# Altium schematic sheet Teensy Connections for SSS2 Rev 5.SchDoc("Teensy Connections for SSS2 Rev 5")
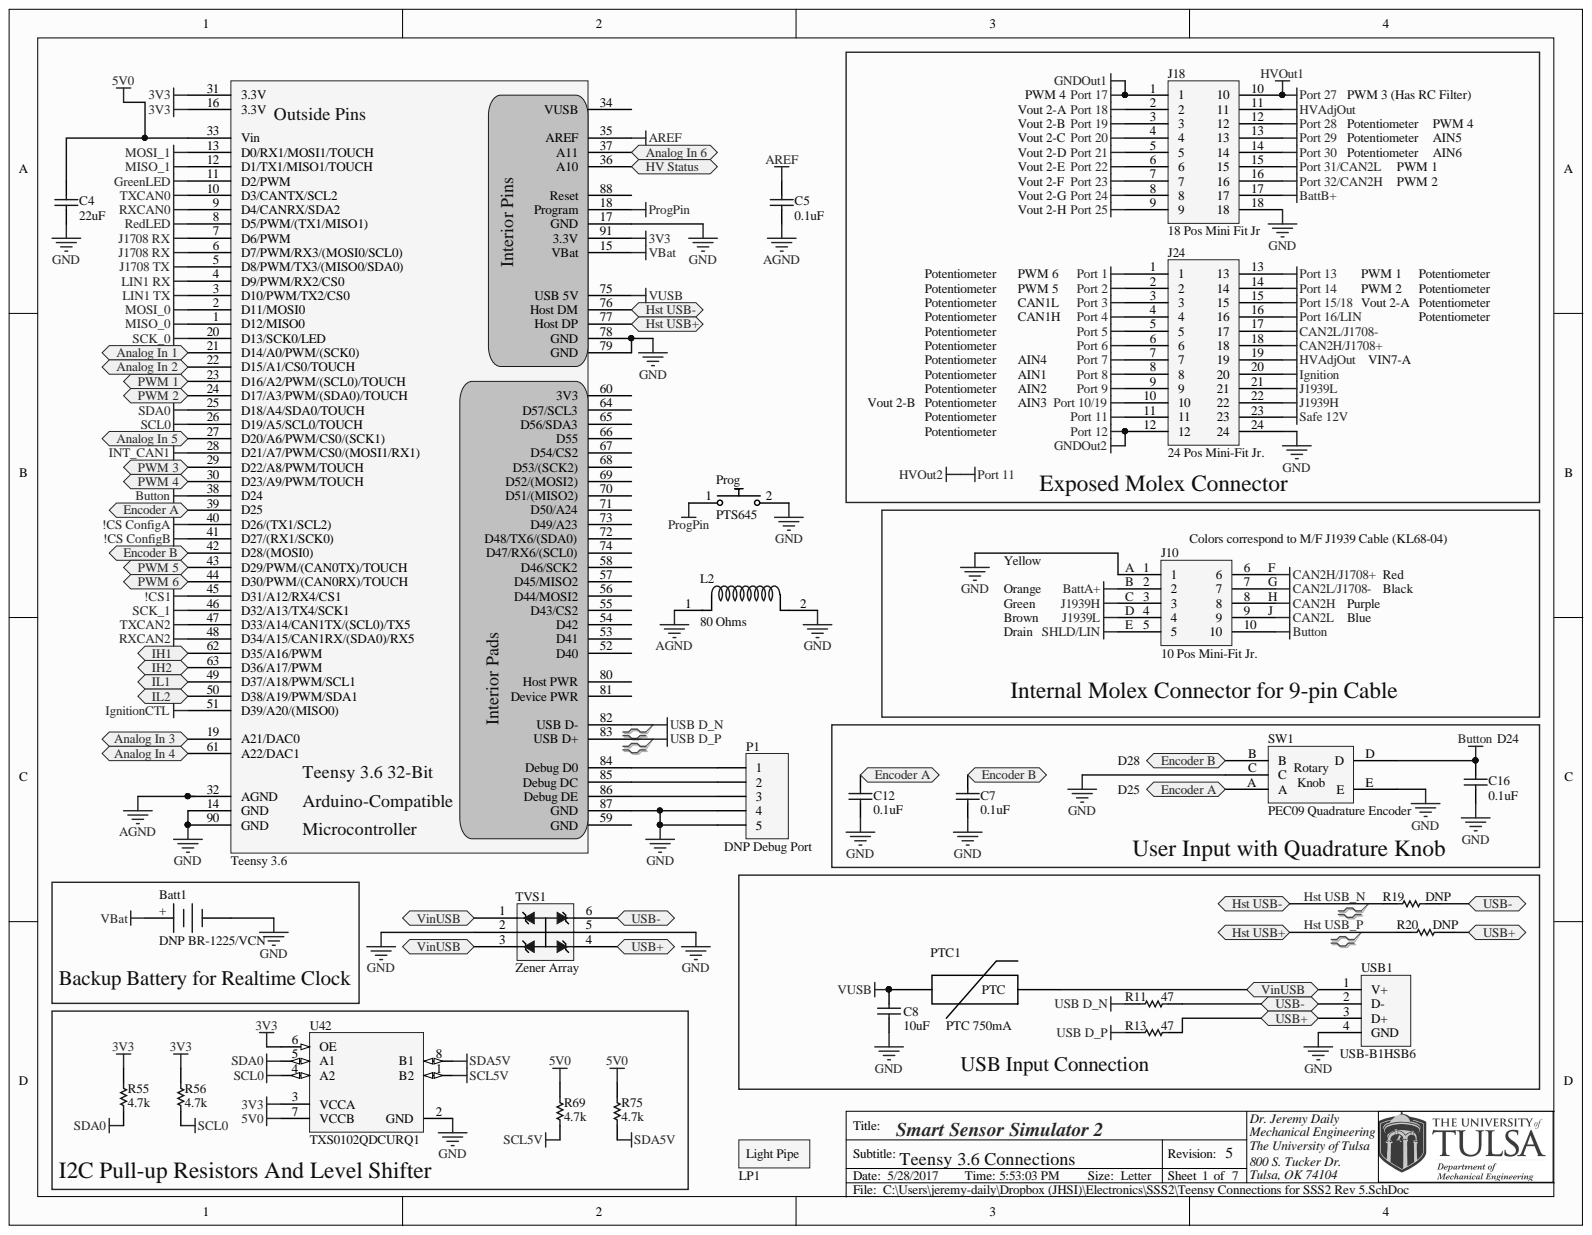
[diagram p0: Teensy Connections for SSS2 Rev 5.SchDoc]

## Parts
Batt1  DNP BR-1225/VCN  PartNumber: BR-1225/VCN  [Coin Cell BR-1225/VCN]
C4  22uF  [1206]
C5  0.1uF  [0603]
C7  0.1uF  [0603]
C8  10uF  [0603]
C12  0.1uF  [0603]
C16  0.1uF  [0603]
J10  10 Pos Mini-Fit Jr.  [10 pos Mini-Fit Jr. R/A Header]
J18  18 Pos Mini Fit Jr  [18 pos Mini-Fit Jr. R/A Header]
J24  24 Pos Mini-Fit Jr.  [24 pos Mini-Fit Jr. R/A Header]
L2  80 Ohms  [0805]
LP1  DNP Light Pipe  [Lightpipe - Dual]  (value not drawn)
P1  DNP Debug Port  [HDR1X5]
Prog  PTS645  [Vertical Tactile Switch]
PTC1  PTC 750mA  [1206]
R11  47  [0603]
R13  47  [0603]
R19  DNP  [0603]
R20  DNP  [0603]
R55  4.7k  [0603]
R56  4.7k  [0603]
R69  4.7k  [0603]
R75  4.7k  [0603]
SW1  PEC09 Quadrature Encoder  [PEC09 Series Encoder]
Teensy_3.6  Teensy 3.6  [Teensy 3.6 Through Hole - No Labels]
TVS1  Zener Array  [SOT23-6]
U42  TXS0102QDCURQ1  [DCU0008A_L]
USB1  USB-B1HSB6  [USB B Connector]

## Ports
ANALOG IN 1, ANALOG IN 2, ANALOG IN 3, ANALOG IN 4, ANALOG IN 5, ANALOG IN 6, ENCODER A, ENCODER B, HST USB+, HST USB-, HV STATUS, IH1, IH2, IL1, IL2, PWM 1, PWM 2, PWM 3, PWM 4, PWM 5, PWM 6, USB+, USB-, VINUSB

## Nets
3V3: R55.2 R56.2 Teensy_3.6.16(3.3V) Teensy_3.6.31(3.3V) Teensy_3.6.91(3.3V) U42.3(VCCA) U42.6(OE)
5V0: C4.2 R69.2 R75.2 Teensy_3.6.33(Vin) U42.7(VCCB)
!CS1: Teensy_3.6.45(D31/A12/RX4/CS1)
!CS CONFIGA: Teensy_3.6.40(D26/(TX1/SCL2))
!CS CONFIGB: Teensy_3.6.41(D27/(RX1/SCK0))
AGND: C5.1 L2.1 Teensy_3.6.32(AGND)
AREF: C5.2 Teensy_3.6.35(AREF)
BATTA+: J10.2
BATTB+: J18.17
BUTTON: C16.2 J10.10 SW1.D Teensy_3.6.38(D24)
CAN2H: J10.8
CAN2H/J1708+: J10.6 J24.18
CAN2L: J10.9
CAN2L/J1708-: J10.7 J24.17
GND: Batt1.2 C4.1 C7.1 C8.2 C12.1 C16.1 J10.1 J18.18 J24.24 L2.2 P1.4 P1.5 Prog.2 SW1.C SW1.E Teensy_3.6.14(GND) Teensy_3.6.17(GND) Teensy_3.6.78(GND) Teensy_3.6.79(GND) Teensy_3.6.87(GND) Teensy_3.6.90(GND) TVS1.2 TVS1.5 U42.2(GND) USB1.4(GND)
GNDOUT1: J18.1
GNDOUT2: J24.12
GREENLED: Teensy_3.6.11(D2/PWM)
HVADJOUT: J18.11 J24.19
HVOUT1: J18.10
HVOUT2: J24.11
IGNITION: J24.20
IGNITIONCTL: Teensy_3.6.51(D39/A20/(MISO0))
INT_CAN1: Teensy_3.6.28(D21/A7/PWM/CS0/(MOSI1/RX1))
J1708 RX: Teensy_3.6.6(D7/PWM/RX3/(MOSI0/SCL0)) Teensy_3.6.7(D6/PWM)
J1708 TX: Teensy_3.6.5(D8/PWM/TX3/(MISO0/SDA0))
J1939H: J10.3 J24.22
J1939L: J10.4 J24.21
LIN1 RX: Teensy_3.6.4(D9/PWM/RX2/CS0)
LIN1 TX: Teensy_3.6.3(D10/PWM/TX2/CS0)
MISO_0: Teensy_3.6.1(D12/MISO0)
MISO_1: Teensy_3.6.12(D1/TX1/MISO1/TOUCH)
MOSI_0: Teensy_3.6.2(D11/MOSI0)
MOSI_1: Teensy_3.6.13(D0/RX1/MOSI1/TOUCH)
PORT 1: J24.1
PORT 2: J24.2
PORT 3: J24.3
PORT 4: J24.4
PORT 5: J24.5
PORT 6: J24.6
PORT 7: J24.7
PORT 8: J24.8
PORT 9: J24.9
PORT 10/19: J24.10
PORT 13: J24.13
PORT 14: J24.14
PORT 15/18: J24.15
PORT 16/LIN: J24.16
PORT 18: J18.2
PORT 19: J18.3
PORT 20: J18.4
PORT 21: J18.5
PORT 22: J18.6
PORT 23: J18.7
PORT 24: J18.8
PORT 25: J18.9
PORT 28: J18.12
PORT 29: J18.13
PORT 30: J18.14
PORT 31/CAN2L: J18.15
PORT 32/CAN2H: J18.16
PROGPIN: Prog.1 Teensy_3.6.18(Program)
REDLED: Teensy_3.6.8(D5/PWM/(TX1/MISO1))
RXCAN0: Teensy_3.6.9(D4/CANRX/SDA2)
RXCAN2: Teensy_3.6.48(D34/A15/CAN1RX/(SDA0)/RX5)
SAFE 12V: J24.23
SCK_0: Teensy_3.6.20(D13/SCK0/LED)
SCK_1: Teensy_3.6.46(D32/A13/TX4/SCK1)
SCL0: R56.1 Teensy_3.6.26(D19/A5/SCL0/TOUCH) U42.4(A2)
SCL5V: R69.1 U42.1(B2)
SDA0: R55.1 Teensy_3.6.25(D18/A4/SDA0/TOUCH) U42.5(A1)
SDA5V: R75.1 U42.8(B1)
SHLD/LIN: J10.5
TXCAN0: Teensy_3.6.10(D3/CANTX/SCL2)
TXCAN2: Teensy_3.6.47(D33/A14/CAN1TX/(SCL0)/TX5)
USB D_N: R11.1 Teensy_3.6.82(USB_D-)
USB D_P: R13.1 Teensy_3.6.83(USB_D+)
VBAT: Batt1.1 Teensy_3.6.15(VBat)
VUSB: C8.1 PTC1.1 Teensy_3.6.75(USB_5V)
HST USB_N: R19.1 Teensy_3.6.76(Host_DM)
HST USB_P: R20.1 Teensy_3.6.77(Host_DP)
IH1: Teensy_3.6.62(D35/A16/PWM) ; port IH1
IH2: Teensy_3.6.63(D36/A17/PWM) ; port IH2
IL1: Teensy_3.6.49(D37/A18/PWM/SCL1) ; port IL1
IL2: Teensy_3.6.50(D38/A19/PWM/SDA1) ; port IL2
PWM 1: Teensy_3.6.23(D16/A2/PWM/(SCL0)/TOUCH) ; port PWM 1
PWM 2: Teensy_3.6.24(D17/A3/PWM/(SDA0)/TOUCH) ; port PWM 2
PWM 3: Teensy_3.6.29(D22/A8/PWM/TOUCH) ; port PWM 3
PWM 4: Teensy_3.6.30(D23/A9/PWM/TOUCH) ; port PWM 4
PWM 5: Teensy_3.6.43(D29/PWM/(CAN0TX)/TOUCH) ; port PWM 5
PWM 6: Teensy_3.6.44(D30/PWM/(CAN0RX)/TOUCH) ; port PWM 6
NetC7_2: C7.2 SW1.B Teensy_3.6.42(D28/(MOSI0)) ; port ENCODER B
NetC12_2: C12.2 SW1.A Teensy_3.6.39(D25) ; port ENCODER A
NetP1_1: P1.1 Teensy_3.6.84(Debug_D0)
NetP1_2: P1.2 Teensy_3.6.85(Debug_DC)
NetP1_3: P1.3 Teensy_3.6.86(Debug_DE)
NetPTC1_2: PTC1.2 TVS1.1 TVS1.3 USB1.1(V+) ; port VINUSB
NetR11_2: R11.2 R19.2 TVS1.6 USB1.2(D-)
NetR13_2: R13.2 R20.2 TVS1.4 USB1.3(D+)
NetTeensy 3.6_19: Teensy_3.6.19(A21/DAC0) ; port ANALOG IN 3
NetTeensy 3.6_21: Teensy_3.6.21(D14/A0/PWM/(SCK0)) ; port ANALOG IN 1
NetTeensy 3.6_22: Teensy_3.6.22(D15/A1/CS0/TOUCH) ; port ANALOG IN 2
NetTeensy 3.6_27: Teensy_3.6.27(D20/A6/PWM/CS0/(SCK1)) ; port ANALOG IN 5
NetTeensy 3.6_36: Teensy_3.6.36(A10) ; port HV STATUS
NetTeensy 3.6_37: Teensy_3.6.37(A11) ; port ANALOG IN 6
NetTeensy 3.6_61: Teensy_3.6.61(A22/DAC1) ; port ANALOG IN 4

Not connected: Teensy_3.6 34(VUSB) 52(D40) 53(D41) 54(D42) 55(D43/CS2) 56(D44/MOSI2) 57(D45/MISO2) 58(D46/SCK2) 59(GND) 60(3V3) 64(D57/SCL3) 65(D56/SDA3) 66(D55) 67(D54/CS2) 68(D53/(SCK2)) 69(D52/(MOSI2)) 70(D51/(MISO2)) 71(D50/A24) 72(D48/TX6/(SDA0)) 73(D49/A23) 74(D47/RX6/(SCL0)) 80(Host_PWR) 81(Device_PWR) 88(Reset)

## Library data of the parts
Batt1 = CR2032 Battery Holder: Lithium Battery Non-Rechargeable (Primary) 3V Coin, 12.5mm; Manufacturer: Panasonic; Component Kind: Standard; Library Name: SSS Integrated Library.IntLib; Supplier 1: Digi-Key; Supplier Part Number 1: P192-ND
C4 = Cap: 22µF ±20% 25V Ceramic Capacitor X5R 1206 (3216 Metric); Manufacturer: Murata Electronics North America; PartNumber: GRT31CR61E226ME01L; Component Kind: Standard; Library Name: SSS Integrated Library.IntLib; Signal Integrity: Cap; Simulation: CAP; Supplier 1: Digi-Key; Supplier Part Number 1: 490-12451-1-ND
C5 C7 C12 C16 = Cap: CAP CER 0.1UF 50V 10% X7R 0603; Manufacturer: Murata Electronics North America; PartNumber: GRM188R71H104KA93D; Component Kind: Standard; Library Name: SSS Schematic Library.SchLib; Signal Integrity: Cap; Simulation: CAP; Supplier 1: Digi-Key; Supplier Part Number 1: 490-1519-1-ND
C8 = Cap: 10µF ±20% 25V Ceramic Capacitor X5R 0603 (1608 Metric); Manufacturer: Murata Electronics North America; PartNumber: GRT188R61E106ME13D; Component Kind: Standard; Library Name: SSS Integrated Library.IntLib; Signal Integrity: Cap; Simulation: CAP; Supplier 1: Digi-Key; Supplier Part Number 1: 490-12323-1-ND
J10 = 10 Pos Header: 10 Positions Header - Shrouded Connector 0.165in (4.20mm) Through Hole - Right Angle Gold; Manufacturer: Molex; Component Kind: Standard; Library Name: Molex Mini-Fit Jr.IntLib; PartNumber: 39-30-1102; Supplier 1: Digi-Key; Supplier Part Number 1: WM21367-ND
J18 = 18 Pos Header: 18 Positions Header - Shrouded Connector 0.165in (4.20mm) Through Hole - Right Angle Gold; Manufacturer: Molex; Component Kind: Standard; Library Name: Molex Mini-Fit Jr.IntLib; PartNumber: 39-30-1182; Supplier 1: Digi-Key; Supplier Part Number 1: WM21371-ND
J24 = 24 Pos Header: 24 Positions Header  Shrouded Connector 0.165in (4.20mm) Through Hole  Right Angle Gold; Manufacturer: Molex; Component Kind: Standard; Library Name: Molex Mini-Fit Jr.IntLib; PartNumber: 39-30-1242; Supplier 1: Digi-Key; Supplier Part Number 1: WM21374-ND
L2 = Ferrite Bead: Ferrite Bead 80ohms 100MHz 5A Monolithic 0805 SMD; Manufacturer: Laird Technologies; PartNumber: HI0805R800R-10; Component Kind: Standard; Library Name: SSS Integrated Library.IntLib; Supplier 1: Mouser; Supplier Part Number 1: 875-HI0805R800R-10
LP1 = Light Pipe: Light Pipe 2 High Clear Rigid Round with Domed Top, 3mm Board Mount, Press Fit, Right Angle; Manufacturer: Bivar Inc.; PartNumber: LP-230; Component Kind: Standard; Library Name: SSS Schematic Library.SchLib; Supplier 1: Digi-Key; Supplier Part Number 1: 492-1859-ND
P1 = Header 5: Header, 5-Pin; Component Kind: Standard; Library Name: Miscellaneous Connectors.IntLib; Signal Integrity: Connector
Prog = Switch - Tactile: PTS645 Series  6 mm Tact Switches; Manufacturer: C & K Components; PartNumber: PTS645VL39-2 LFS; Component Kind: Standard; Library Name: TU Custom Parts Schematics.SchLib; Supplier 1: Digi-Key; Supplier Part Number 1: CKN9103-ND
PTC1 = PTC: PTC RESTTBLE 0.75A 16V CHIP 1206; Manufacturer: Bel Fuse Inc; PartNumber: 0ZCJ0075AF2E; Component Kind: Standard; Library Name: SSS Schematic Library.SchLib; Supplier 1: Digi-Key; Supplier Part Number 1: 507-1805-1-ND
R11 R13 R19 R20 = Chip Resistor: RES SMD 47 OHM 1% 1/10W 0603; Manufacturer: Panasonic Electronic Components; PartNumber: ERJ-3EKF47R0V; Component Kind: Standard; Library Name: SSS Integrated Library.IntLib; Supplier 1: Digi-Key; Supplier Part Number 1: P47.0HCT-ND
R55 R56 R69 R75 = Chip Resistor: RES SMD 4.7K OHM 1% 1/10W 0603; Manufacturer: Panasonic Electronic Components; PartNumber: ERJ-3EKF4701V; Component Kind: Standard; Library Name: SSS Integrated Library.IntLib; Supplier 1: Digi-Key; Supplier Part Number 1: P4.70KHCT-ND
SW1 = Quadrature PEC09: ENCODER INCREMENTAL W/SWITCH 9MM; Manufacturer: Bourns Inc.; PartNumber: PEC09-2220F-S0012; Component Kind: Standard; Library Name: SSS Integrated Library.IntLib; Supplier 1: Digi-Key; Supplier Part Number 1: PEC09-2220F-S0012-ND
Teensy_3.6 = Teensy 3.6: 32 Bit Arduino-Compatible Microcontroller; Manufacturer: PRJC; Component Kind: Standard; Library Name: Teensy.IntLib; PartNumber: Teensy 3.6; Supplier 1: SparkFun; Supplier Part Number 1: DEV-14057
TVS1 = TVS Diode Array: TVS DIODE 5VWM 6.8VC SOT23; Manufacturer: Wurth Electronics Inc; PartNumber: 82402304; Component Kind: Standard; Library Name: Protection Devices.IntLib; Supplier 1: Digi-Key; Supplier Part Number 1: 732-4476-1-ND
U42 = TXS0102QDCURQ1: Voltage Level Translator Bidirectional 1 Circuit 2 Channel 24Mbps US8; Manufacturer: Texas Instruments; Component Kind: Standard; Library Name: TI_Logic_Little_Logic.IntLib; PartNumber: TXS0102QDCURQ1; Supplier 1: Digi-Key; Supplier Part Number 1: 296-44866-1-ND
USB1 = USB-Rugged: USB - B Receptacle Connector 4 Position Through Hole, Right Angle, Horizontal; Manufacturer: On Shore Technology Inc.; Component Kind: Standard; Library Name: SSS Integrated Library.IntLib; PartNumber: USB-B1HSB6; Supplier 1: Digi-Key; Supplier Part Number 1: ED2983-ND
